# Altium schematic sheet Power.SchDoc
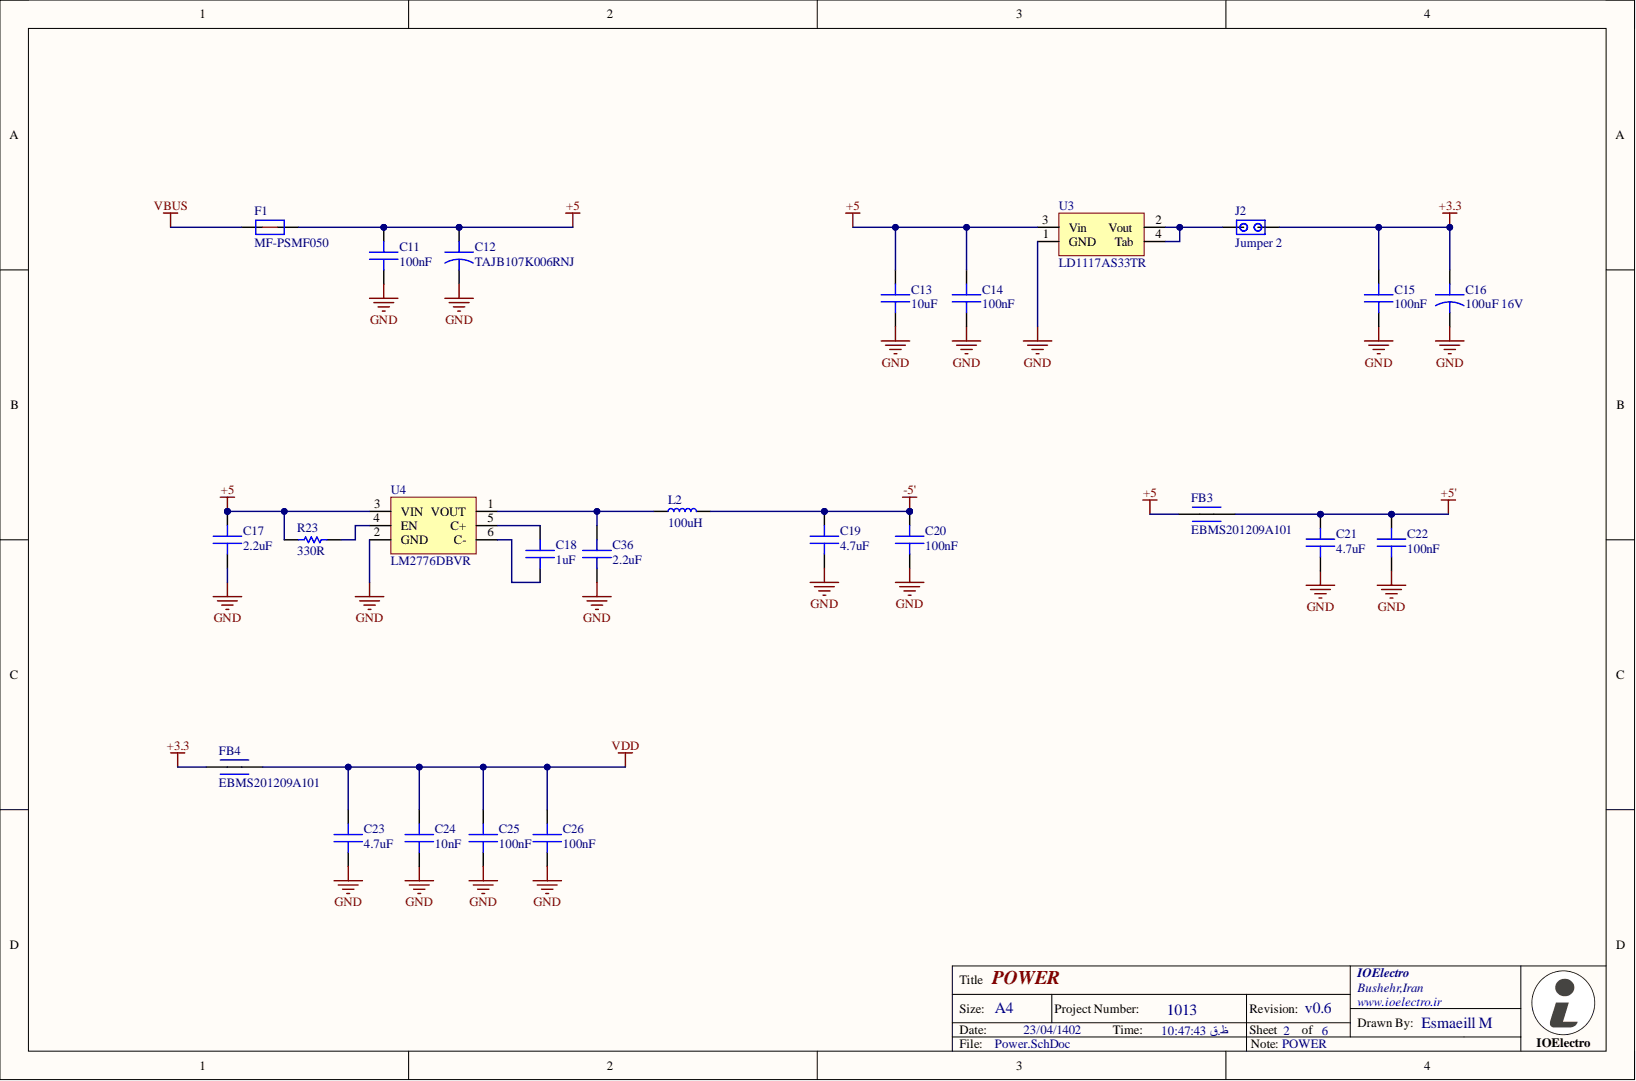
[diagram p0: Power.SchDoc]

## Parts
C11  100nF  [C-0805]
C12  TAJB107K006RNJ  [TAJB]
C13  10uF  [C-0805]
C14  100nF  [C-0805]
C15  100nF  [C-0805]
C16  100uF 16V  [C-EL_6.5x7x2.5mm]
C17  2.2uF  [C-0805]
C18  1uF  [C-0805]
C19  4.7uF  [C-0805]
C20  100nF  [C-BP-Ceramic-DIP]
C21  4.7uF  [C-0805]
C22  100nF  [C-BP-Ceramic-DIP]
C23  4.7uF  [C-0805]
C24  10nF  [C-0805]
C25  100nF  [C-0805]
C26  100nF  [C-0805]
C36  2.2uF  [C-0805]
F1  MF-PSMF050  [MF-PSMF]
FB3  EBMS201209A101  [L-0805]
FB4  EBMS201209A101  [L-0805]
J2  Jumper 2  [JAMPER-2-DIP_2.56mm]
L2  100uH  [L-DIP_3x7mm_STAND]
R23  330R  [R-0805-R]
U3  LD1117AS33TR  [SOT-223]
U4  LM2776DBVR  [SOT-23-6-(lm2776)]

## Nets
+3.3: C15.1 C16.A FB4.1 J2.1
+5: C11.1 C12.A C13.1 C14.1 C17.1 F1.2 FB3.1 R23.2 U3.3(Vin) U4.3(VIN)
+5': C21.1 C22.2 FB3.2
-5': C19.1 C20.2 L2.2
GND: C11.2 C12.K C13.2 C14.2 C15.2 C16.K C17.2 C19.2 C20.1 C21.2 C22.1 C23.2 C24.2 C25.2 C26.2 C36.2 U3.1(GND) U4.2(GND)
VBUS: F1.1
VDD: C23.1 C24.1 C25.1 C26.1 FB4.2
NetC18_1: C18.1 U4.5(C+)
NetC18_2: C18.2 U4.6(C-)
NetC36_1: C36.1 L2.1 U4.1(VOUT)
NetJ2_2: J2.2 U3.2(Vout) U3.4(Tab)
NetR23_1: R23.1 U4.4(EN)

## Library data of the parts
C11 C13-C15 C19 C21 C23-C26 = CAP: SMD 0805; Component Kind: Standard; Library Name: IOElectro.IntLib
C12 = TAJB107K006RNJ: AVX - TAJB107K006RNJ - Surface Mount Tantalum Capacitor, 100 µF, 6.3 V, 1411 [3528 Metric], TAJ Series, ± 10%, -55 °C; Manufacturer: Kyocera AVX; Capacitance: 100uF; ESR (Equivalent Series Resistance): 1.7R; Leakage Current: 6.3uA; Polarity: Polar; Voltage: 6.3V; Case Code (Imperial): 1210; Case Code (Metric): 3528; Case/Package: 1210; Category: Tantalum Capacitors; Component Kind: Standard; ComponentLink1Description: https://datasheet.ciiva.com/11794/0900766b802e69d0-11794415.pdf?src-supplier=RSComponents; ComponentLink1URL: https://datasheet.ciiva.com/11794/0900766b802e69d0-11794415.pdf?src-supplier=RSComponents; ComponentLink2Description: https://datasheet.ciiva.com/26915/getdatasheetpartid-133381-26915174.pdf?src-supplier=Verical; ComponentLink2URL: https://datasheet.ciiva.com/26915/getdatasheetpartid-133381-26915174.pdf?src-supplier=Verical; ComponentLink3Description: https://datasheet.ciiva.com/18710/taj-eng-18710634.pdf?src-supplier=Distrelec; ComponentLink3URL: https://datasheet.ciiva.com/18710/taj-eng-18710634.pdf?src-supplier=Distrelec; ComponentLink4Description: https://datasheet.ciiva.com/7720/1680476-7720606.pdf?src-supplier=Element14; ComponentLink4URL: https://datasheet.ciiva.com/7720/1680476-7720606.pdf?src-supplier=Element14; ComponentLink5Description: https://datasheet.ciiva.com/7720/1680476-7720607.pdf?src-supplier=Element14; ComponentLink5URL: https://datasheet.ciiva.com/7720/1680476-7720607.pdf?src-supplier=Element14; ComponentLink6Description: https://datasheet.ciiva.com/264/taj-264188.pdf?src-supplier=Digi-Key; ComponentLink6URL: https://datasheet.ciiva.com/264/taj-264188.pdf?src-supplier=Digi-Key; ComponentLink7Description: https://datasheet.ciiva.com/264/taj-264187.pdf?src-supplier=Digi-Key; ComponentLink7URL: https://datasheet.ciiva.com/264/taj-264187.pdf?src-supplier=Digi-Key; ComponentLink8Description: https://datasheet.ciiva.com/264/taj-264189.pdf?src-supplier=Digi-Key; ComponentLink8URL: https://datasheet.ciiva.com/264/taj-264189.pdf?src-supplier=Digi-Key; ComponentLink9Description: https://datasheet.ciiva.com/14602/70001050-14602126.pdf?src-supplier=Allied+Electronics; ComponentLink9URL: https://datasheet.ciiva.com/14602/70001050-14602126.pdf?src-supplier=Allied+Electronics; ComponentLink10Description: https://datasheet.ciiva.com/14599/70001050-14599717.pdf?src-supplier=Allied+Electronics; ComponentLink10URL: https://datasheet.ciiva.com/14599/70001050-14599717.pdf?src-supplier=Allied+Electronics; ComponentLink11Description: https://datasheet.ciiva.com/11224/taj-11224955.pdf?src-supplier=Avnet; ComponentLink11URL: https://datasheet.ciiva.com/11224/taj-11224955.pdf?src-supplier=Avnet; ComponentLink12Description: https://datasheet.ciiva.com/16632/taj-16632597.pdf?src-supplier=Avnet+Europe; ComponentLink12URL: https://datasheet.ciiva.com/16632/taj-16632597.pdf?src-supplier=Avnet+Europe; Construction: Flat; Depth: 2.8mm; Dielectric Material: Tantalum; Dissipation Factor: 10%; Features: General Purpose; Height: 1.9mm; Height - Seated (Max): 0.083inch; Lead Free: Lead Free; Length: 3.5mm; Library Name: IOElectro.IntLib; Manufacturer Part Number: TAJB107K006RNJ; Max Operating Temperature: 125°C; Min Operating Temperature: -55°C; Mount: Surface Mount,PCB; Package Quantity: 1; Packaging: Tape and Reel; Pricing 1: 1=0/71000, 10=0/52500, 50=0/41980, 100=0/35980, 500=0/28486, 1000=0/23989 (USD); Radiation Hardening: No; REACH SVHC: No SVHC; RoHS: Yes; RoHS Compliant: Yes; Series: TAJ; Stock 1: 10000; Supplier 1: Digi-Key; Supplier Part Number 1: 478-1677-1-ND; Termination: SMD/SMT; Tolerance: 10%; Voltage Rating: 6.3V; Voltage Rating (DC): 6.3V; Width: 2.8mm
C16 = CAP-EL: CAPACITOR ELECTROLYTIC 6.3x7mm; Component Kind: Standard; Library Name: IOElectro.IntLib
C17 C18 C36 = CAP: CAPACITOR BIPOLAR; Component Kind: Standard; Library Name: IOElectro.IntLib
C20 C22 = CAP: Ceramic Disk Capacitor 50V; Component Kind: Standard; Library Name: IOElectro.IntLib
F1 = MF-PSMF050X-2: BOURNS   MF-PSMF050X-2   FUSE, RESETTABLE PTC, 6V, 500MA, 0805; Approvals: UL; Current Rating: 40A; Max Power Dissipation: 500mW; Number of Terminals/Pins: 2; Resistance: 900mR; Time to Trip: 0.1s; Trip Current: 1A; Case Code (Imperial): 0805; Case Code (Metric): 2012; Case/Package: 0805; Category: PTC Resettable Fuses; Component Kind: Standard; ComponentLink1Description: https://datasheet.ciiva.com/3019/mfpsmf-3019798.pdf?src-supplier=Avnet; ComponentLink1URL: https://datasheet.ciiva.com/3019/mfpsmf-3019798.pdf?src-supplier=Avnet; ComponentLink2Description: https://datasheet.ciiva.com/3019/mfpsmf-3019799.pdf?src-supplier=Avnet; ComponentLink2URL: https://datasheet.ciiva.com/3019/mfpsmf-3019799.pdf?src-supplier=Avnet; ComponentLink3Description: https://datasheet.ciiva.com/22657/1442129-22657847.pdf?src-supplier=Element14; ComponentLink3URL: https://datasheet.ciiva.com/22657/1442129-22657847.pdf?src-supplier=Element14; ComponentLink4Description: https://datasheet.ciiva.com/26946/getdatasheetpartid-137409-26946007.pdf?src-supplier=Verical; ComponentLink4URL: https://datasheet.ciiva.com/26946/getdatasheetpartid-137409-26946007.pdf?src-supplier=Verical; ComponentLink5Description: https://datasheet.ciiva.com/326/mfpsmf-326488.pdf?src-supplier=Digi-Key; ComponentLink5URL: https://datasheet.ciiva.com/326/mfpsmf-326488.pdf?src-supplier=Digi-Key; ComponentLink6Description: https://datasheet.ciiva.com/326/mfpsmf-326489.pdf?src-supplier=Digi-Key; ComponentLink6URL: https://datasheet.ciiva.com/326/mfpsmf-326489.pdf?src-supplier=Digi-Key; ComponentLink7Description: https://datasheet.ciiva.com/326/mfpsmf-326490.pdf?src-supplier=Digi-Key; ComponentLink7URL: https://datasheet.ciiva.com/326/mfpsmf-326490.pdf?src-supplier=Digi-Key; ComponentLink8Description: https://datasheet.ciiva.com/4501/mfpsmf-69505-4501179.pdf?src-supplier=Mouser; ComponentLink8URL: https://datasheet.ciiva.com/4501/mfpsmf-69505-4501179.pdf?src-supplier=Mouser; ComponentLink9Description: https://datasheet.ciiva.com/32430/mf-psmf-series-eng-tds-32430651.pdf?src-supplier=Distrelec; ComponentLink9URL: https://datasheet.ciiva.com/32430/mf-psmf-series-eng-tds-32430651.pdf?src-supplier=Distrelec; ComponentLink10Description: https://datasheet.ciiva.com/1274/1442129-1274589.pdf?src-supplier=Element14; ComponentLink10URL: https://datasheet.ciiva.com/1274/1442129-1274589.pdf?src-supplier=Element14; ComponentLink11Description: https://datasheet.ciiva.com/17401/mfpsmf-17401741.pdf?src-supplier=Avnet+Europe; ComponentLink11URL: https://datasheet.ciiva.com/17401/mfpsmf-17401741.pdf?src-supplier=Avnet+Europe; ComponentLink12Description: https://datasheet.ciiva.com/22712/1442129-22712191.pdf?src-supplier=Element14; ComponentLink12URL: https://datasheet.ciiva.com/22712/1442129-22712191.pdf?src-supplier=Element14; Height: 0.85mm; Hold Current: 500mA; Length: 2.3mm; Library Name: IOElectro.IntLib; Manufacturer: Bourns; Manufacturer Part Number: MF-PSMF050X-2; Max Current Rating: 40A; Max Operating Temperature: 85°C; Min Operating Temperature: -40°C; Mount: Surface Mount,PCB; Number of Pins: 2; Operating Supply Voltage: 6V; Package Quantity: 3000; Packaging: Tape and Reel; Power Dissipation: 500mW; Pricing 1: 1=0/80000, 5=0/78000, 10=0/75600, 50=0/63600, 100=0/52800, 250=0/48000, 500=0/40800, 1000=0/36000 (USD); Radiation Hardening: No; REACH SVHC: No SVHC; RoHS: Yes; RoHS Compliant: Yes; Series: MF-PSMF; Stock 1: 9973; Supplier 1: Digi-Key; Supplier Part Number 1: MF-PSMF050X-2CT-ND; Terminal Material: Gold; Termination: SMD/SMT; Voltage Rating: 6V; Voltage Rating (DC): 6V; Width: 1.5mm
FB3 FB4 = EBMS201209A101: FERRITE BEAD 0805 100R 100Mhz; Component Kind: Standard; Library Name: Schlib.SchLib
J2 = JUMPER-2: 2PIN DIP JAMPER 2.56mm; Component Kind: Standard; Library Name: IOElectro.IntLib
L2 = INDUCTOR: Component Kind: Standard; Description: INDUCTOR; Library Name: IOElectro.IntLib
R23 = RES: RESISTOR; Component Kind: Standard; Library Name: Schlib.SchLib
U3 = LD1117AS33TR: IC REG LINEAR 3.3V 1A SOT223; Manufacturer: STMicroelectronics; Accuracy: 2%; Dropout Voltage: 1.15V; Input Bias Current: 5mA; Max Input Voltage: 10V; Max Output Current: 1.2A; Max Power Dissipation: 12W; Min Input Voltage: 5.3V; Number of Outputs: 1; Output Type: Fixed; Polarity: Positive; Quiescent Current: 10mA; Case/Package: SOT-223; Category: Voltage Regulators - Linear; Component Kind: Standard; ComponentLink1Description: https://datasheet.ciiva.com/7993/1732936-7993322.pdf?src-supplier=Element14; ComponentLink1URL: https://datasheet.ciiva.com/7993/1732936-7993322.pdf?src-supplier=Element14; ComponentLink2Description: https://datasheet.ciiva.com/7993/1732936-7993323.pdf?src-supplier=Element14; ComponentLink2URL: https://datasheet.ciiva.com/7993/1732936-7993323.pdf?src-supplier=Element14; ComponentLink3Description: https://datasheet.ciiva.com/16375/cd00002116-16375420.pdf?src-supplier=Future+Electronics; ComponentLink3URL: https://datasheet.ciiva.com/16375/cd00002116-16375420.pdf?src-supplier=Future+Electronics; ComponentLink4Description: https://datasheet.ciiva.com/2042/cd00002116-2042573.pdf?src-supplier=Digi-Key; ComponentLink4URL: https://datasheet.ciiva.com/2042/cd00002116-2042573.pdf?src-supplier=Digi-Key; ComponentLink5Description: https://datasheet.ciiva.com/2042/cd00002116-2042574.pdf?src-supplier=Digi-Key; ComponentLink5URL: https://datasheet.ciiva.com/2042/cd00002116-2042574.pdf?src-supplier=Digi-Key; ComponentLink6Description: https://datasheet.ciiva.com/2042/cd00002116-2042575.pdf?src-supplier=Digi-Key; ComponentLink6URL: https://datasheet.ciiva.com/2042/cd00002116-2042575.pdf?src-supplier=Digi-Key; Contact Plating: Tin,Matte; Height: 1.8mm; Lead Free: Lead Free; Length: 6.5mm; Library Name: IOElectro.IntLib; Manufacturer Part Number: LD1117AS33TR; Max Operating Temperature: 125°C; Min Operating Temperature: 0°C; Min Output Voltage: 3.3V; Mount: Surface Mount; Nominal Output Voltage: 3.3V; Number of Pins: 4; Number of Regulators: 1; Output Current: 1A; Output Voltage: 3.3V; Output Voltage Accuracy: 2%; Package Quantity: 1; Packaging: Tape and Reel; Power Dissipation: 12W; Pricing 1: 1=0/98000, 10=0/86000, 25=0/80760, 100=0/65920, 250=0/61228, 500=0/52110, 1000=0/43015 (USD); Radiation Hardening: No; REACH SVHC: No SVHC; RoHS: Yes; RoHS Compliant: Yes; Series: -; Stock 1: 4724; Supplier 1: Digi-Key; Supplier Part Number 1: 497-1228-1-ND; Weight: 0.01lb; Width: 3.5mm
U4 = LM2776DBVR: Charge Pump INV -2.7V to -5.5V 200mA 6-Pin SOT-23 T/R / IC REG SWTCHD CAP INV 6SOT23; Manufacturer: Texas Instruments; Logic Function: Inverting; Max Input Voltage: 5.5V; Min Input Voltage: 2.7V; Number of Outputs: 1; Output Configuration: Negative; Output Type: Fixed; Quiescent Current: 100uA; Switching Frequency: 2MHz; Synchronous Rectifier: No; Case/Package: SOT-23-6; Category: Voltage Regulators - Switching; Component Kind: Standard; ComponentLink1Description: http://www.ti.com/general/docs/lit/getliterature.tsp?genericPartNumber=LM2776&&fileType=pdf&src-supplier=Avnet; ComponentLink1URL: http://www.ti.com/general/docs/lit/getliterature.tsp?genericPartNumber=LM2776&&fileType=pdf&src-supplier=Avnet; ComponentLink2Description: http://www.ti.com/lit/ds/symlink/lm2776.pdf?src-supplier=Farnell; ComponentLink2URL: http://www.ti.com/lit/ds/symlink/lm2776.pdf?src-supplier=Farnell; ComponentLink3Description: http://www.ti.com/lit/ds/symlink/lm2776.pdf?src-supplier=Digi-Key; ComponentLink3URL: http://www.ti.com/lit/ds/symlink/lm2776.pdf?src-supplier=Digi-Key; Lead Free: Lead Free; Library Name: IOElectro.IntLib; Manufacturer Part Number: LM2776DBVR; Max Operating Temperature: 85°C; Min Operating Temperature: -40°C; Mount: Surface Mount; Number of Pins: 6; Output Current: 200mA; Output Voltage: -5.5V; Packaging: Tape and Reel; Pricing 1: 1=1/14000, 10=1/01900, 25=0/96760, 100=0/79480, 250=0/74296, 500=0/65656, 1000=0/57050 (USD); RoHS: Yes; RoHS Compliant: Yes; Supplier 1: Digi-Key; Supplier Part Number 1: 296-43957-1-ND; Topology: Charge Pump
